# Altium schematic sheet Sheet3.SchDoc
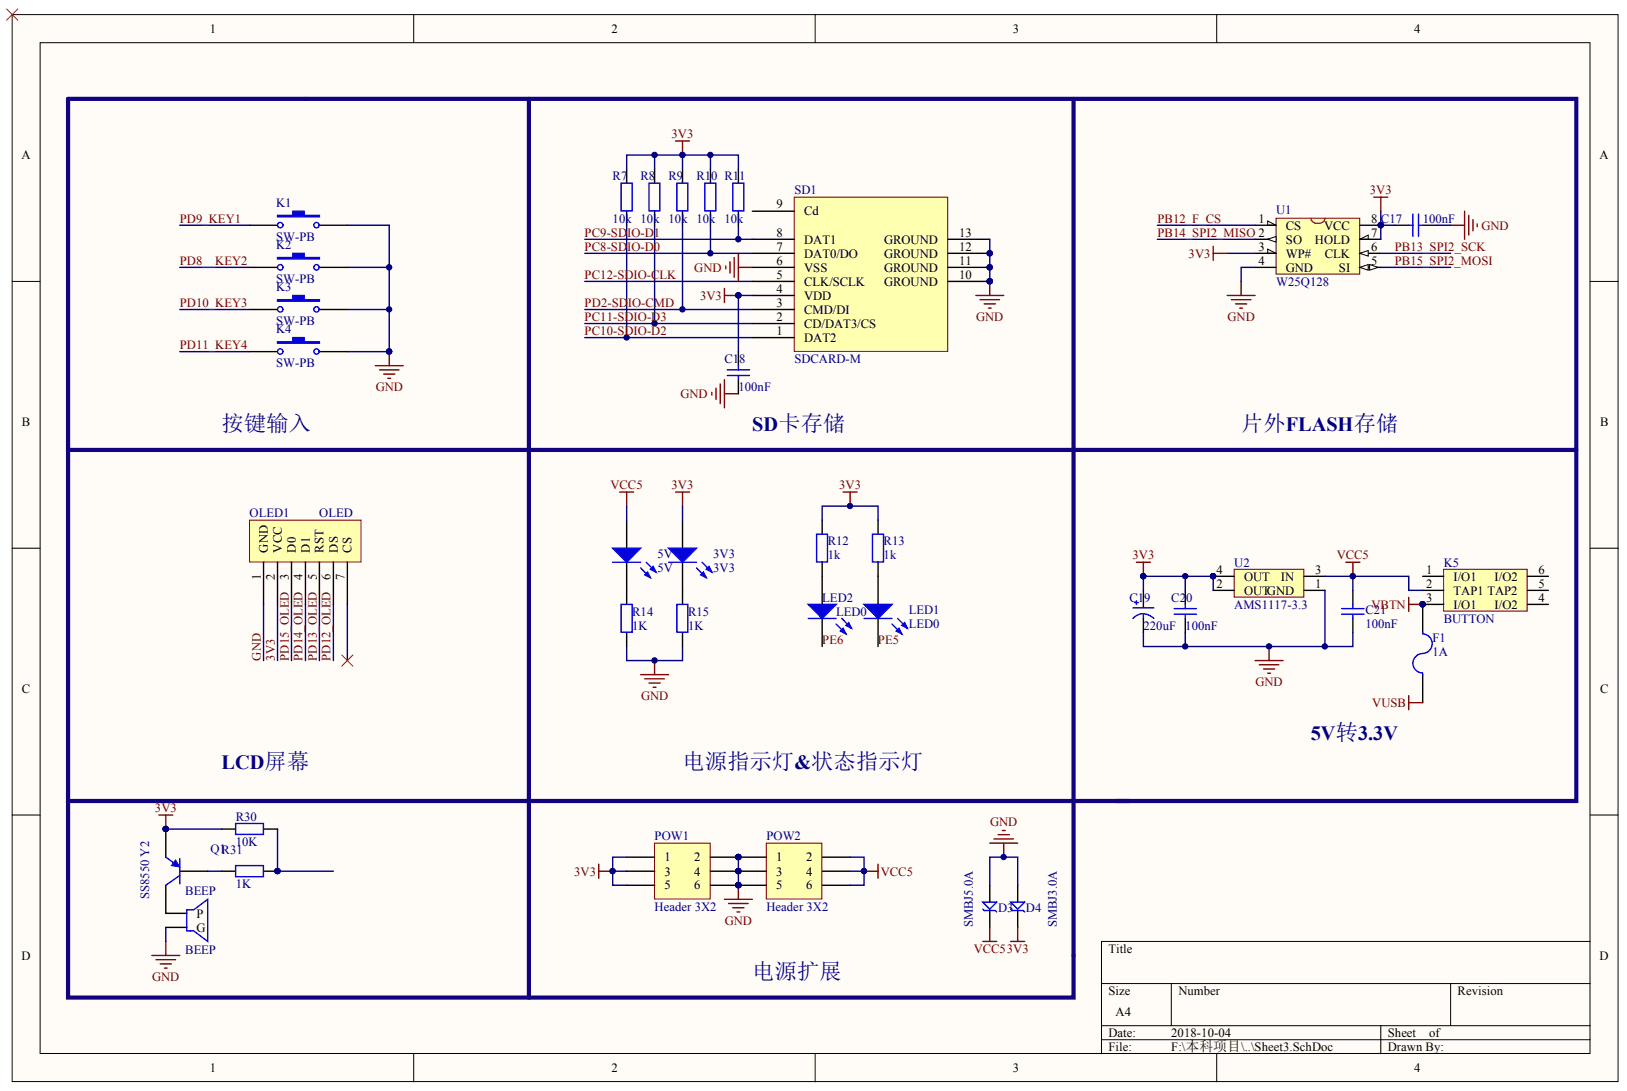
[diagram p0: Sheet3.SchDoc]

## Parts
3V3  3V3
5V  5V
BEEP  BEEP
C17  100nF
C18  100nF
C19  220uF
C20  100nF
C21  100nF
D3  SMBJ5.0A
D4  SMBJ3.0A
F1  value 1A  (hidden comment: Fuse)
K1  SW-PB
K2  SW-PB
K3  SW-PB
K4  SW-PB
K5  BUTTON
LED1  LED0
LED2  LED0
OLED1  OLED
POW1  Header 3X2
POW2  Header 3X2
Q1  SS8550 Y2
R7  10k
R8  10k
R9  10k
R10  10k
R11  10k
R12  1k
R13  1k
R14  1K
R15  1K
R30  10K
R31  1K
SD1  SDCARD-M
U1  W25Q128
U2  AMS1117-3.3

## Nets
VBTN: F1.2 K5.3(I/O1)
VCC5: 5V.1 C21.2 D3.2 K5.2(TAP1) POW2.2 POW2.4 POW2.6 U2.3(IN)
VUSB: F1.1
3V3: 3V3.1 C17.2 C18.2 C19.1 C20.2 D4.2 OLED1.2(VCC) POW1.1 POW1.3 POW1.5 Q1.2 R7.1 R8.1 R9.1 R10.1 R11.1 R12.1 R13.1 R30.2 SD1.4(VDD) U1.3(WP#) U1.7(HOLD) U1.8(VCC) U2.2(OUT) U2.4(OUT)
GND: BEEP.2 C17.1 C18.1 C19.2 C20.1 C21.1 D3.1 D4.1 K1.2 K2.2 K3.2 K4.2 OLED1.1(GND) POW1.2 POW1.4 POW1.6 POW2.1 POW2.3 POW2.5 R14.1 R15.1 SD1.6(VSS) SD1.10(GROUND) SD1.11(GROUND) SD1.12(GROUND) SD1.13(GROUND) U1.4(GND) U2.1(GND)
PB12_F_CS: U1.1(CS)
PB13_SPI2_SCK: U1.6(CLK)
PB14_SPI2_MISO: U1.2(SO)
PB15_SPI2_MOSI: U1.5(SI)
PC8-SDIO-D0: R10.2 SD1.7(DAT0/DO)
PC9-SDIO-D1: R11.2 SD1.8(DAT1)
PC10-SDIO-D2: R7.2 SD1.1(DAT2)
PC11-SDIO-D3: R8.2 SD1.2(CD/DAT3/CS)
PC12-SDIO-CLK: SD1.5(CLK/SCLK)
PD2-SDIO-CMD: R9.2 SD1.3(CMD/DI)
PD8__KEY2: K2.1
PD9_KEY1: K1.1
PD10_KEY3: K3.1
PD11_KEY4: K4.1
PD12_OLED: OLED1.6(DS)
PD13_OLED: OLED1.5(RST)
PD14_OLED: OLED1.4(D1)
PD15_OLED: OLED1.3(D0)
PE5: LED1.2
PE6: LED2.2
Net3V3_2: 3V3.2 R15.2
Net5V_2: 5V.2 R14.2
NetBEEP_1: BEEP.1 Q1.3
NetLED1_1: LED1.1 R13.2
NetLED2_1: LED2.1 R12.2
NetQ1_1: Q1.1 R31.2
NetR30_1: R30.1 R31.1

Not connected: K5 1(I/O1) 4(I/O2) 5(TAP2) 6(I/O2); OLED1 7(CS); SD1 9(Cd)

## Library data of the parts
R7-R11 = (no symbol name): Value: 1K; Published: 8-Jun-2000; LatestRevisionDate: 17-Jul-2002; LatestRevisionNote: Re-released for DXP Platform.; PackageReference: AXIAL-0.4; Publisher: Altium Limited
3V3 5V BEEP C17-C21 D3 D4 F1 K1-K5 LED1 LED2 OLED1 POW1 POW2 Q1 R12-R15 R30 R31 SD1 U1 U2 = (no symbol name)
  3V3 5V LED1 LED2: Published: 8-Jun-2000; LatestRevisionDate: 08-Jul-2005; LatestRevisionNote: Mech Layer 1 removed.; PackageReference: LED-0
  C17 C18 C20 C21: Published: 8-Jun-2000; LatestRevisionDate: 17-Jul-2002; LatestRevisionNote: Re-released for DXP Platform.; PackageReference: RAD-0.3
  F1: Published: 8-Jun-2000; LatestRevisionDate: 17-Jul-2002; LatestRevisionNote: Re-released for DXP Platform.; PackageReference: PIN-W2/E2.8
  K1-K4: Published: 24-Mar-1999; LatestRevisionDate: 17-Jul-2002; LatestRevisionNote: Re-released for DXP Platform.; PackageReference: SPST-2; PackageDescription: Switch; 2 Leads
  POW1 POW2: LatestRevisionDate: 17-Jul-2002; LatestRevisionNote: Re-released for DXP Platform.
  Q1: ComponentLink1Description: 供应商链接; ComponentLink1URL: http://www.szlcsc.com/product/details_9037.html; Package: SOT-23; Supplier: LC; SuppliersPartNumber: C8542
  R12 R13: Published: 8-Jun-2000; LatestRevisionDate: 17-Jul-2002; LatestRevisionNote: Re-released for DXP Platform.; PackageReference: AXIAL-0.4; Value: 1K
  R14 R15 R30 R31: ComponentLink1Description: 供应商链接; ComponentLink1URL: http://www.szlcsc.com/product/details_23491.html; Package: 0603; Supplier: LC; SuppliersPartNumber: C22764
  3V3 5V C17 C18 C20 C21 F1 K1-K4 LED1 LED2 POW1 POW2 R12 R13: Publisher: Altium Limited
